# Altium schematic sheet Analog PSU.SchDoc("Analog PSU")
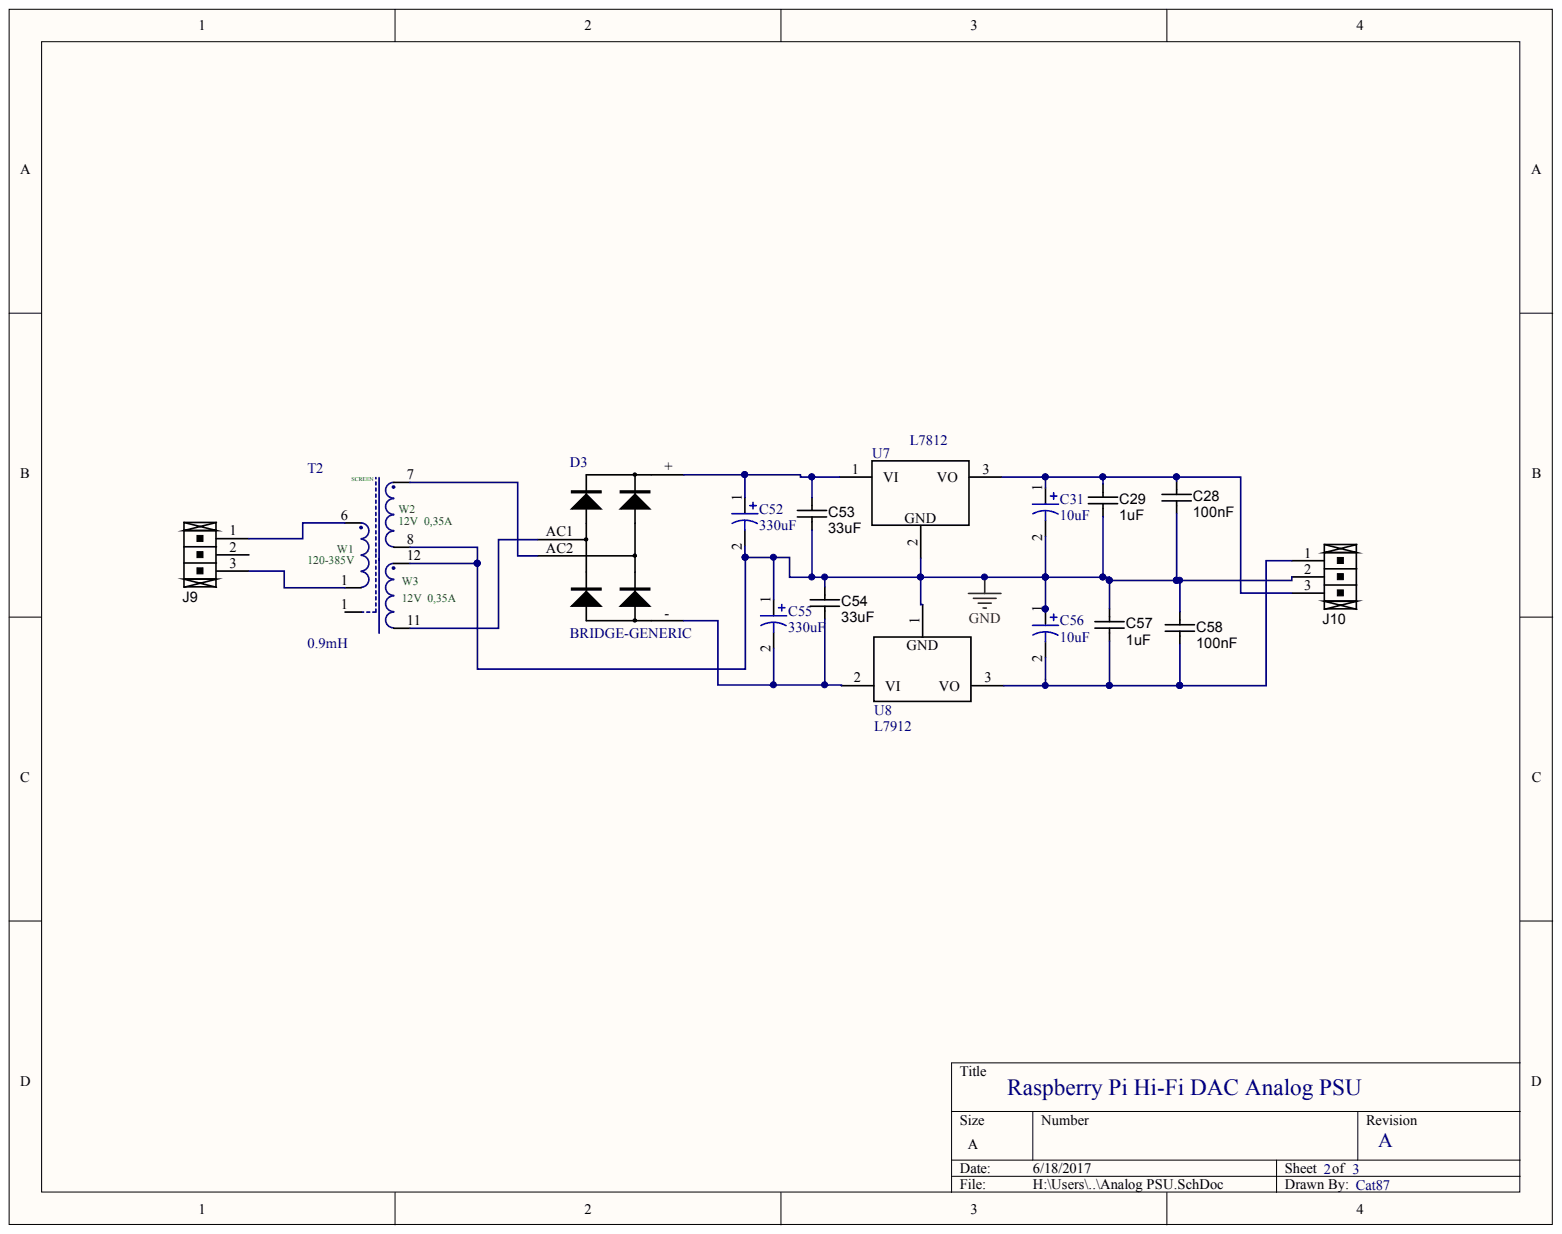
[diagram p0: Analog PSU.SchDoc]

## Parts
C28  value 100nF  (hidden comment: approved sub OK)
C29  value 1uF  (hidden comment: approved sub OK)
C31  10uF
C52  330uF
C53  value 33uF  (hidden comment: approved sub OK)
C54  value 33uF  (hidden comment: approved sub OK)
C55  330uF
C56  10uF
C57  value 1uF  (hidden comment: approved sub OK)
C58  value 100nF  (hidden comment: approved sub OK)
D3  BRIDGE-GENERIC
J9  3P_TERM BLOCK HEADER  (value not drawn)
J10  3P_TERM BLOCK HEADER  (value not drawn)
T2  0.9mH
U7  L7812
U8  L7912

## Nets
GND: C28.2 C29.1 C31.2 C52.2 C53.1 C54.2 C55.1 C56.1 C57.2 C58.1 J10.2 T2.8 T2.12 U7.2 U8.1
NetC28_1: C28.1 C29.2 C31.1 J10.3 U7.3
NetC52_1: C52.1 C53.2 D3.+ U7.1
NetC54_1: C54.1 C55.2 D3.- U8.2
NetC56_2: C56.2 C57.1 C58.2 J10.1 U8.3
NetD3_AC1: D3.AC1 T2.11
NetD3_AC2: D3.AC2 T2.7
NetJ9_1: J9.1 T2.6
NetJ9_3: J9.3 T2.1

Not connected: J9 2

## Library data of the parts
C28 C29 C53 C54 C57 C58 = (no symbol name): Manufacturer 1: TDK Corporation; Manufacturer Part Number 1: C1608X7R1H103K; Tolerance: ±10%; Ratings: 50V; X7R; Material: ceramic; SCDType: spec; Package / Case: 0603 (1608 metric); Supplier 1: Digi-Key; Supplier Part Number 1: 445-1311-1-ND; ComponentLink1URL: http://product.tdk.com/capacitor/mlcc/en/documents/mlcc_commercial_general_en.pdf; ComponentLink1Description: http://product.tdk.com/capacitor/mlcc/en/documents/mlcc_commercial_general_en.pdf; Supplier 2: Digi-Key; Supplier Part Number 2: 478-1227-1-ND; Manufacturer 2: AVX Corporation; Manufacturer Part Number 2: 06035C103KAT2A; ComponentLink2URL: http://www.avx.com/docs/Catalogs/cx7r.pdf; ComponentLink2Description: http://www.avx.com/docs/Catalogs/cx7r.pdf
C31 C56 = (no symbol name): Manufacturer: Wurth Elektronik; partNo: 865080443007; E-mail: libraries@we-online.com; Capacitance: 47 μF; Characteristics: Aluminum Electrolytic Capacitor;Recommended soldering: Reflow;Operating temperature: –55 °C to +105 °C;Low impedance product series;Load life: 2000 h @ +105 °C; ComponentLink1Description: Manufacturer; ComponentLink1URL: http://www.we-online.com; ComponentLink2Description: Datasheet; ComponentLink2URL: http://katalog.we-online.de/pbs/datasheet/865080443007.pdf; Created Date: 5/13/2015; Application: Energy storage or high frequency filtering in the low voltage circuit; used as power supply filtering in general switching power supply, DC-DC converter, AC-DC converter, conversion adapter, charger, TV, VCR, DC motor circuit filter, digital audio, digital TV, digital camera, digital video camera, satellite receiver, equipment control panel and etc.; Created by: Karrer Zheng
C52 C55 = (no symbol name): Manufacturer: Wurth Elektronik; partNo: 865080153013; E-mail: libraries@we-online.com; Capacitance: 680 μF; Characteristics: Aluminum Electrolytic Capacitor;Recommended soldering: Reflow;Operating temperature: –55 °C to +105 °C;Low impedance product series;Load life: 2000 h @ +105 °C; ComponentLink1Description: Manufacturer; ComponentLink1URL: http://www.we-online.com; ComponentLink2Description: Datasheet; ComponentLink2URL: http://katalog.we-online.de/pbs/datasheet/865080153013.pdf; Created Date: 5/13/2015; Application: Energy storage or high frequency filtering in the low voltage circuit; used as power supply filtering in general switching power supply, DC-DC converter, AC-DC converter, conversion adapter, charger, TV, VCR, DC motor circuit filter, digital audio, digital TV, digital camera, digital video camera, satellite receiver, equipment control panel and etc.; Created by: Karrer Zheng
J9 J10 = (no symbol name): Manufacturer 1: TE Connectivity; Manufacturer Part Number 1: 284517-3; Pitch: 0.150" (3.81mm); Voltage: 300V; Mating Connector: 284507-3; Value: 3 position; Positions Per Level: 3; SCDType: undef; Category: Connectors; Mounting Type: Through Hole; Terminal Block Type: Header, Male Pins, Shrouded (4 Side); Package / Case: Side Entry; 3.81mm pitch; Supplier 1: Digi-Key; Supplier Part Number 1: A98411-ND; ComponentLink1URL: http://www.te.com/commerce/DocumentDelivery/DDEController?Action=srchrtrv&DocNm=284517&DocType=Customer+Drawing&DocLang=English; ComponentLink1Description: http://www.te.com/commerce/DocumentDelivery/DDEController?Action=srchrtrv&DocNm=284517&DocType=Customer+Drawing&DocLang=English
T2 = (no symbol name): PartNo: 7491182112; Manufacturer: Würth Elektronik eiSos GmbH; Turns Ratio: 9.5:1; Output Current (A): 0.25; Output Voltage(DC): 12; Input Voltage (AC): 85-265; Inductance: 0.9mH; ComponentLink1Description: Manufacturer; ComponentLink1URL: http://www.we-online.de; Created Date: OCT 2013; ComponentLink2Description: Datasheet; ComponentLink2URL: http://www.we-online.de/katalog/media/pdf/7491182112.pdf; Created by: Otto Christin
D3 U7 U8 = (no symbol name)
  U7: Supplier 1: Digi-Key; Supplier Part Number 1: 497-1170-6-ND
  U8: Supplier 1: Mouser; Supplier Part Number 1: 511-L7912ACV
